# Altium schematic sheet Top.SchDoc
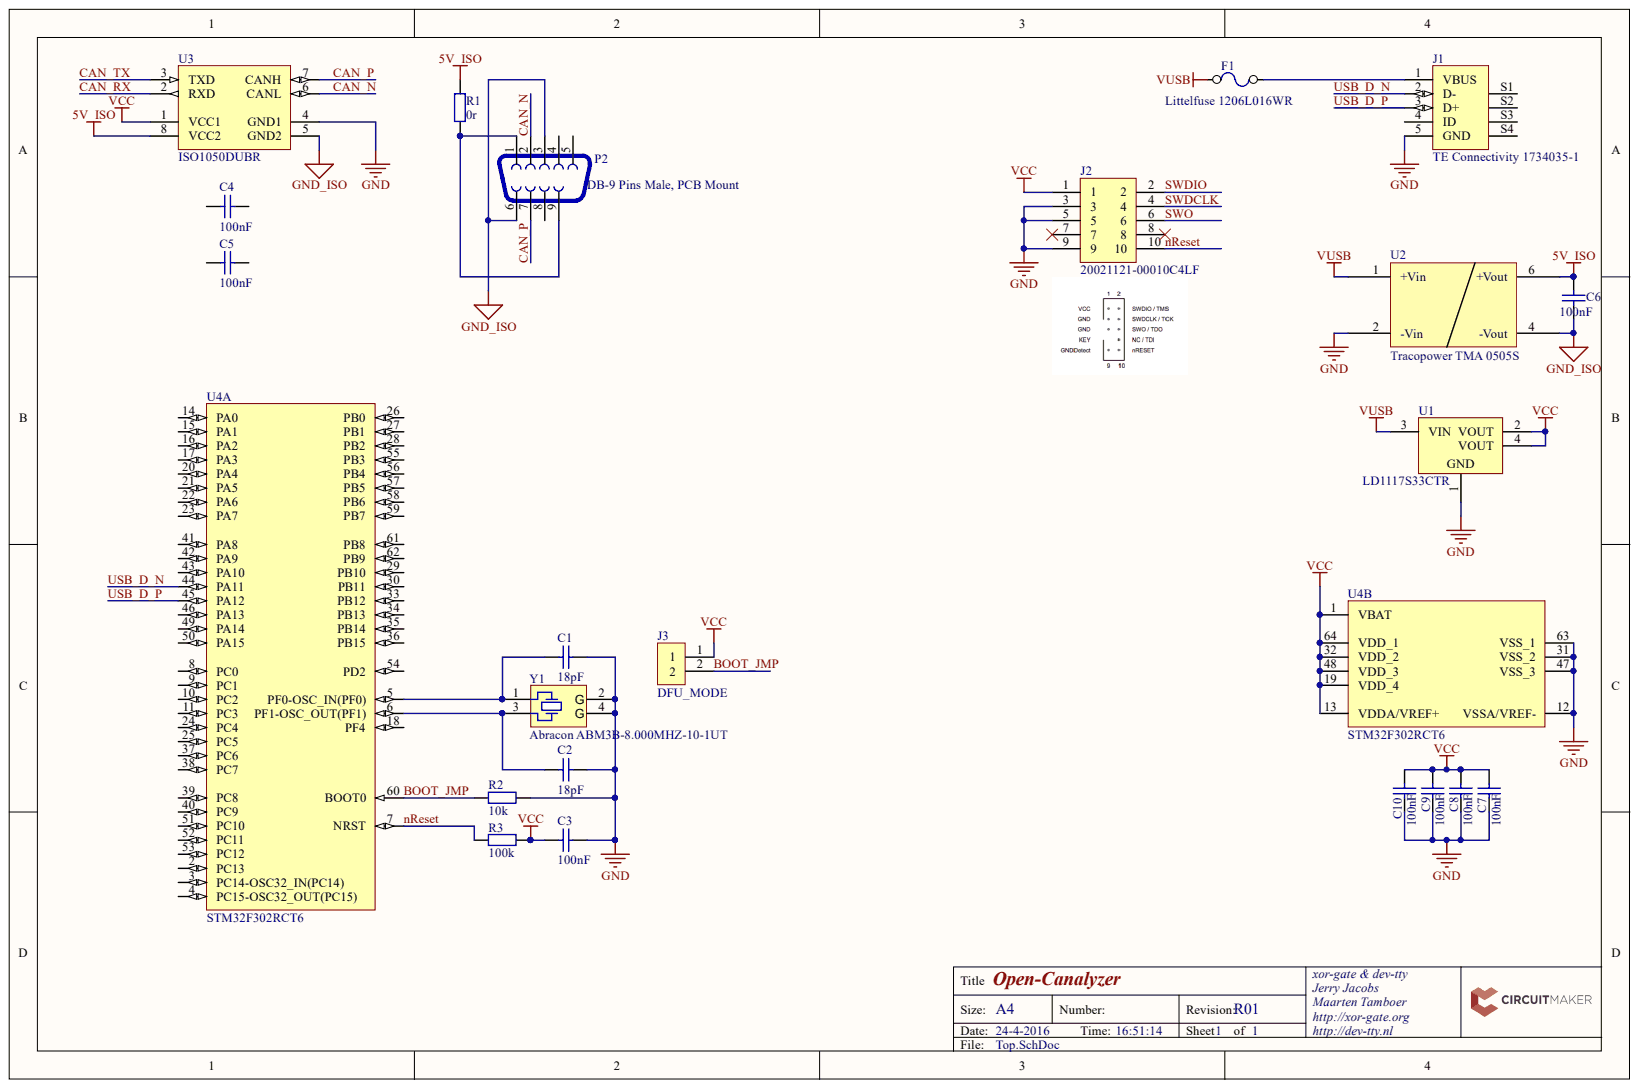
[diagram p0: Top.SchDoc]

## Parts
C1  18pF  [PCB-8u3bf2z8rexgmcet8v16-1]
C2  18pF  [PCB-8u3bf2z8rexgmcet8v16-1]
C3  100nF  [PCB-8u3bf2z8rexgmcet8v16-1]
C4  100nF  [PCB-8u3bf2z8rexgmcet8v16-1]
C5  100nF  [PCB-8u3bf2z8rexgmcet8v16-1]
C6  100nF  [PCB-8u3bf2z8rexgmcet8v16-1]
C7  100nF  [PCB-8u3bf2z8rexgmcet8v16-1]
C8  100nF  [PCB-8u3bf2z8rexgmcet8v16-1]
C9  100nF  [PCB-8u3bf2z8rexgmcet8v16-1]
C10  100nF  [PCB-8u3bf2z8rexgmcet8v16-1]
F1  Littelfuse 1206L016WR  [CAPC3216X127X50LL20T25]
J1  TE Connectivity 1734035-1  Manufacturer Part Number: 1734035-1  [PCB-92xbr658ff5005b6v25i-1]
J2  20021121-00010C4LF  [PCB-345154-1]
J3  DFU_MODE  [PCB-5yupddsicqn8qq7gr5lb-1]
P2  DB-9 Pins Male, PCB Mount  [PCB-t2r1bfvo6rsnmeykqezm-1]
R1  0r  [PCB-jbjp1sagbj5faggo6atp-1]
R2  10k  [PCB-jbjp1sagbj5faggo6atp-1]
R3  100k  [PCB-jbjp1sagbj5faggo6atp-1]
U1  LD1117S33CTR  [SOT-223_M]
U2  Tracopower TMA 0505S  Manufacturer Part Number: TMA 0505S  [PCB-a34l2xuwcfq5ykblouz8-1]
U3  ISO1050DUBR  [DUB8_M]
U4  STM32F302RCT6  [STM-LQFP64_M]  (parts A,B)
Y1  Abracon ABM3B-8.000MHZ-10-1UT  [PCB-3272631-1]

## Nets
5V_ISO: C6.2 R1.2 U2.6(+Vout) U3.8(VCC2)
GND: C1.2 C2.2 C3.2 C7.2 C8.2 C9.2 C10.2 J1.5(GND) J2.3 J2.5 J2.9 R2.2 U1.1 U2.2(-Vin) U3.4(GND1) U4.12(VSSA/VREF-) U4.31(VSS_2) U4.47(VSS_3) U4.63(VSS_1) Y1.2(G) Y1.4(G)
GND_ISO: C6.1 P2.3 P2.6 U2.4(-Vout) U3.5(GND2)
VCC: C3.1 C7.1 C8.1 C9.1 C10.1 J2.1 J3.1 R3.2 U1.2(VOUT) U1.4(VOUT) U3.1(VCC1) U4.1(VBAT) U4.13(VDDA/VREF+) U4.19(VDD_4) U4.32(VDD_2) U4.48(VDD_3) U4.64(VDD_1)
VUSB: F1.1 U1.3(VIN) U2.1(+Vin)
BOOT_JMP: J3.2 R2.1 U4.60(BOOT0)
CAN_N: P2.2 U3.6(CANL)
CAN_P: P2.7 U3.7(CANH)
CAN_RX: U3.2(RXD)
CAN_TX: U3.3(TXD)
NRESET: J2.10 R3.1 U4.7(NRST)
SWDCLK: J2.4
SWDIO: J2.2
SWO: J2.6
USB_D_N: J1.2(D-) U4.44(PA11)
USB_D_P: J1.3(D+) U4.45(PA12)
NetC1_1: C1.1 U4.5(PF0-OSC_IN(PF0)) Y1.1
NetC2_1: C2.1 U4.6(PF1-OSC_OUT(PF1)) Y1.3
NetF1_2: F1.2 J1.1(VBUS)
NetP2_1: P2.1 P2.9 R1.1

Not connected: C4 1 2; C5 1 2; J1 4(ID) S1 S2 S3 S4; J2 7 8; P2 4 5 8; U4 2(PC13) 3(PC14-OSC32_IN(PC14)) 4(PC15-OSC32_OUT(PC15)) 8(PC0) 9(PC1) 10(PC2) 11(PC3) 14(PA0) 15(PA1) 16(PA2) 17(PA3) 18(PF4) 20(PA4) 21(PA5) 22(PA6) 23(PA7) 24(PC4) 25(PC5) 26(PB0) 27(PB1) 28(PB2) 29(PB10) 30(PB11) 33(PB12) 34(PB13) 35(PB14) 36(PB15) 37(PC6) 38(PC7) 39(PC8) 40(PC9) 41(PA8) 42(PA9) 43(PA10) 46(PA13) 49(PA14) 50(PA15) 51(PC10) 52(PC11) 53(PC12) 54(PD2) 55(PB3) 56(PB4) 57(PB5) 58(PB6) 59(PB7) 61(PB8) 62(PB9)

## Library data of the parts
C1-C10 = CMP-8u3bf2z8rexgmcet8v16-A.1: Capacitor 0805; Component Kind: Standard; CustomComponentID: 8u3bf2z8rexgmcet8v16; Library Name: CommunityVault
F1 = CMP-277446-4: PTC RESET 30V .160A SMD 1206; Holding Current: 0.16 A; Lead-Free Status: Lead Free; Operating Voltage: 30.0 V; Power Dissipation: 0.6 W; Tripping Current: 0.37 A; Component Kind: Standard; Library Name: CommunityVault; OctopartID: 16cadfca74c2c90d; Octopart Url: https://octopart.com/1206l016wr-littelfuse-673646; Packaging: Cut Tape (CT); REACH SVHC Compliance: No SVHC; RoHS: Compliant; Voltage Rating (DC): 30.0 V
J1 = CMP-778005-8: USB Connectors 5P MINI TYPE B RECPT SMT WITH POSTS; ELV Compliant: Compliant; Gender: Female; Lead-Free Status: Lead Free; Number of Contacts: 5; Component Kind: Standard; Contact Material: Phosphor Bronze; Flammability Rating: UL 94 V-0; Housing Color: Black; Housing Material: Thermoplastic; Library Name: CommunityVault; Lifecycle Status: Active; Number of Positions: 5; OctopartID: 9a20517374d345e7; Octopart Url: https://octopart.com/1734035-1-te+connectivity-39439253; Orientation: Right Angle; Packaging: Tray; REACH SVHC Compliance: No SVHC; RoHS: Compliant; Size-Height: 0.0039 m; Size-Length: 0.00845 m; Size-Width: 0.0077 m
J2 = CMP-345154-9: CONN HEADER 10POS DL UNSHRD SMD; Company: FCI; Gender: Male; Number of Contacts: 10; Component Kind: Standard; Contact Material: Copper Alloy; Contact Plating: Gold; Library Name: CommunityVault; OctopartID: f63c3ff63b2429fb; Octopart Url: https://octopart.com/20021121-00010c4lf-fci-12642430; REACH SVHC Compliance: No SVHC; RoHS: Compliant
J3 = CMP-2a80e1d15423f547-12: 2 Position header; Manufacturer Part Number: 3-644456-2; ELV Compliant: Compliant; Gender: Male; Lead-Free Status: Lead Free; Mounting Angle: 180 °; Number of Contacts: 2; Pin Pitch: 2.54 mm; Shrouded: No; Voltage Rating (AC): 250 V; Component Kind: Standard; Contact Material: Copper Alloy; Contact Plating: Tin; Flammability Rating: UL 94 V-0; Housing Color: Natural; Housing Material: Polyester; Library Name: CommunityVault; Lifecycle Status: Active; Mounting Style: Through Hole; Number of Positions: 2; Number of Rows: 1; OctopartID: 2a80e1d15423f547; Octopart URL: http://octopart.com/3-644456-2-te+connectivity-39541701; Orientation: Vertical; Packaging: Box, Bag; RoHS: Compliant; Size-Height: 2.54 mm; Size-Length: 4.78 mm; Size-Width: 2.34 mm
P2 = CMP-00accaeb48cadf6b-11: D-Sub Connector Right Angle 9 Pins, Male Stamped Pin, PCB Mount with 7.2mm Footprint; Manufacturer Part Number: 1734354-1; ELV Compliant: Compliant; Lead-Free Status: Lead Free; Number of Contacts: 9; Number of Mating Cycles: 100; Pin Pitch: 0.00277 m; Component Kind: Standard; Contact Material: Phosphor Bronze; Contact Plating: Gold Flash, Tin; ES: http://www.es.co.th/detail.asp?Prod=000712914; Flammability Rating: UL 94 V-0; Gender: Male; Housing Color: Black; Housing Material: Nylon; Library Name: CommunityVault; Lifecycle Status: Active; Material: Metal; Mounting Style: Through Hole; Number of Positions: 9; Number of Rows: 2; OctopartID: 00accaeb48cadf6b; Octopart URL: http://octopart.com/1734354-1-te+connectivity-55405824; Orientation: Right Angle; Packaging: Tray, Box; REACH SVHC Compliance: No SVHC; RoHS: Compliant; Size-Height: 0.0125 m; Size-Length: 0.0308 m; Size-Width: 0.0184 m
R1-R3 = CMP-jbjp1sagbj5faggo6atp-A.2: Resistor 0805; Component Kind: Standard; CustomComponentID: jbjp1sagbj5faggo6atp; Library Name: CommunityVault
U1 = CMP-1170392-2: Fixed Low Drop Positive Voltage Regulator, 3.3V, 4-Pin SOT-223, Tape and Reel; Dropout Voltage: 1.1 V; Lead-Free Status: Lead Free; Number of Outputs: 1; Case/Package: SOT-223; Component Kind: Standard; Library Name: CommunityVault; Lifecycle Status: Active; Mounting Style: Surface Mount; Number of Pins: 3; OctopartID: 0754ce562bb7d893; Octopart Url: https://octopart.com/ld1117s33ctr-stmicroelectronics-526725; Output Voltage: 3.3 V; REACH SVHC Compliance: No SVHC; RoHS: Compliant
U2 = CMP-fec637b9144e2101-2: Tma Series 1w \ Input Range ±10%, i/o Isolation 1000 Vdc \ Sip-7 Package (Plastic Case); Input Voltage (DC): 5.0 V; Isolation Voltage: 1000.0 V; Number of Outputs: 1; Output Current: 0.2 A; Power Rating: 1.0 W; Component Kind: Standard; Flammability Rating: UL 94 V-0; Library Name: CommunityVault; Mounting Style: Through Hole; OctopartID: fec637b9144e2101; Octopart URL: http://octopart.com/tma+0505s-tracopower-7720942; Output Voltage: 5.0 V; REACH SVHC Compliance: No SVHC; RoHS: Compliant; Size-Height: 0.0102 m; Size-Width: 0.0061 m; Supply Voltage (DC): 5.0 V; Weight: 2.6 g
U3 = CMP-1158484-2: Isolated CAN Transceiver, -55 to 105 degC, 8-pin SOP (DUB), Green (RoHS & no Sb/Br); Data Rate: 1000000.0 bps; Drivers Per Package: 1; Lead-Free Status: Lead Free; Output Current: 0.07 A; Output Voltage: 5.0 V; Thermal Shutdown: Yes; Case/Package: SOP; Component Kind: Standard; Library Name: CommunityVault; Lifecycle Status: Active; Mounting Style: Surface Mount; Number of Pins: 8; OctopartID: 915958f0b6204e9e; Octopart Url: https://octopart.com/iso1050dubr-texas+instruments-10682911; Packaging: Cut Tape (CT); REACH SVHC Compliance: No SVHC; RoHS: Compliant
U4 = CMP-5648437-3: ARM Cortex-M4 32-bit MCU, 256 KB Flash, 32 KB Internal RAM, 52 I/Os, 64-pin LQFP, -40 to 85 degC, Tray; Clock Speed: 72000000.0 Hz; Lead-Free Status: Lead Free; RAM Memory Size: 32768 B; Component Kind: Standard; Library Name: CommunityVault; Lifecycle Status: Active; Number of Pins: 64; OctopartID: 6977a1fa814bb6b0; Octopart Url: https://octopart.com/stm32f302rct6-stmicroelectronics-25677146; REACH SVHC Compliance: No SVHC; RoHS: Compliant
Y1 = CMP-3272631-2: Crystals 8.0MHz 10pf 10ppm -10C +60C; Load Capacitance: 1e-11 F; Component Kind: Standard; Library Name: CommunityVault; OctopartID: 12bddaab2e63cd7a; Octopart Url: https://octopart.com/abm3b-8.000mhz-10-1ut-abracon-18618808; RoHS: Compliant
